# Altium schematic sheet General Overview.SchDoc("General Overview")
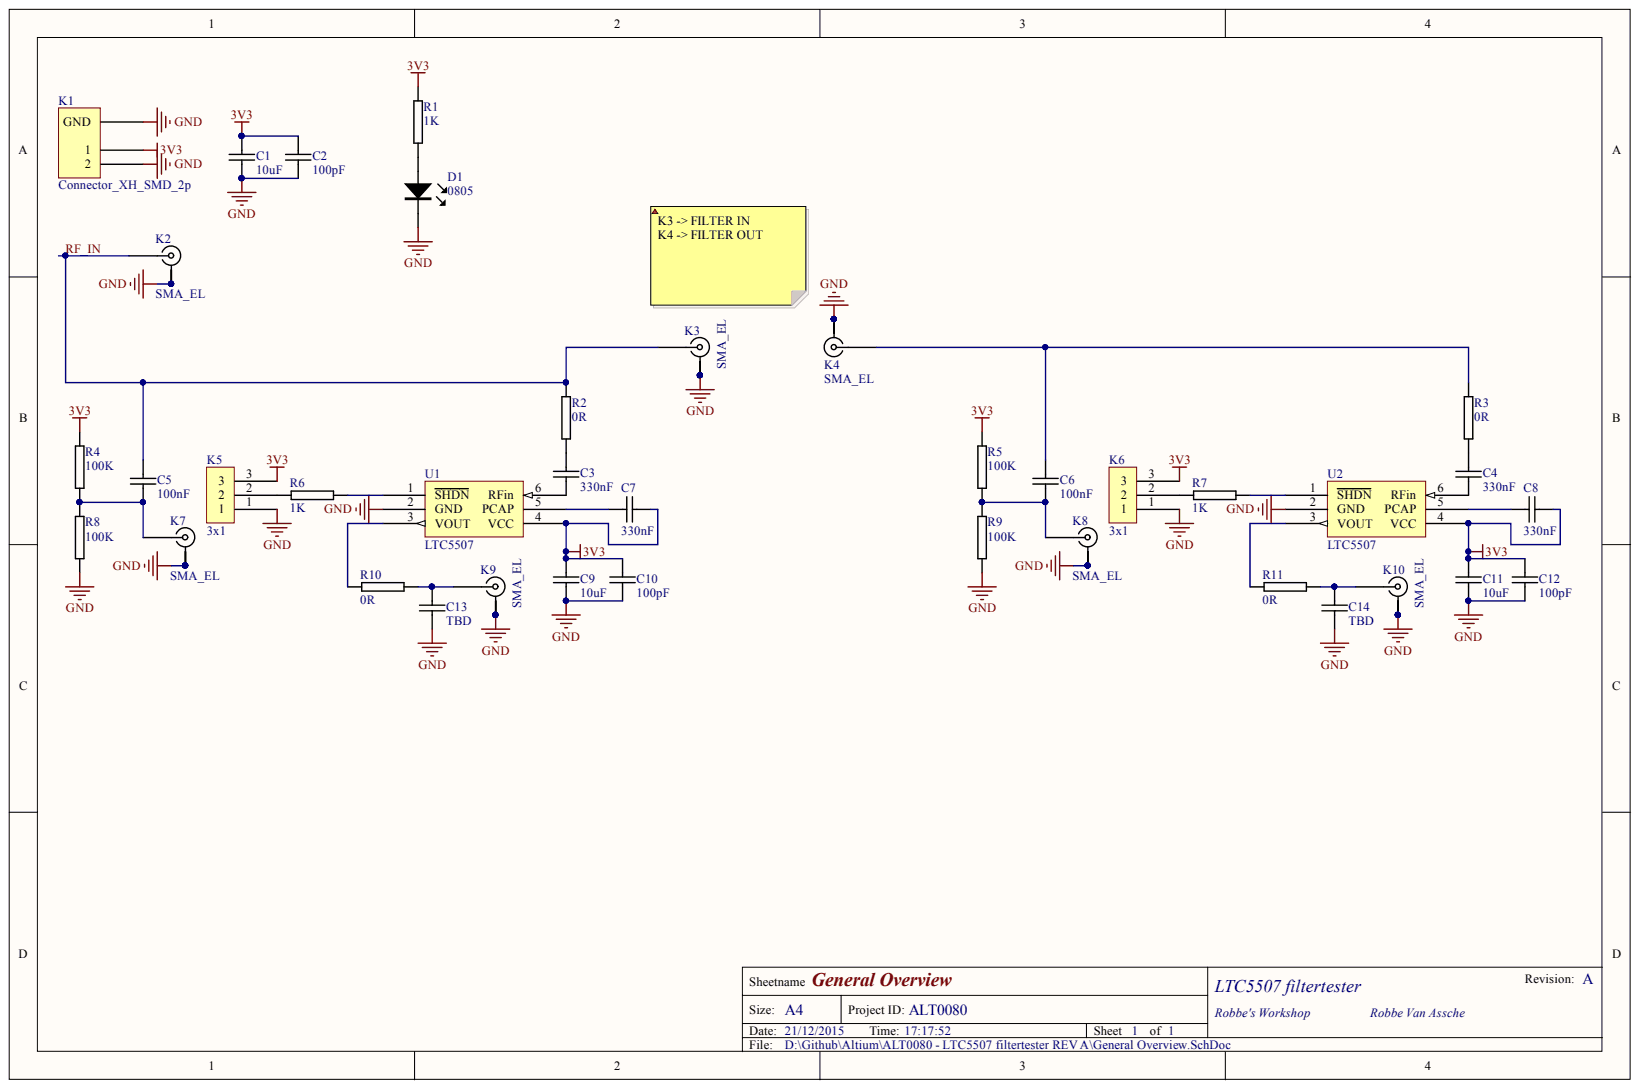
[diagram p0: General Overview.SchDoc]

## Parts
C1  10uF  [1210C-GENERIC]
C2  100pF  [0603C-TBD]
C3  330nF  [0603C-TBD]
C4  330nF  [0603C-TBD]
C5  100nF  [0603C-TBD]
C6  100nF  [0603C-TBD]
C7  330nF  [0603C-TBD]
C8  330nF  [0603C-TBD]
C9  10uF  [1210C-GENERIC]
C10  100pF  [0603C-TBD]
C11  10uF  [1210C-GENERIC]
C12  100pF  [0603C-TBD]
C13  TBD  [0603C-TBD]
C14  TBD  [0603C-TBD]
D1  0805  [0805LED]
K1  Connector_XH_SMD_2p  [2.54_2p]
K2  SMA_EL  [SMA_ENDLAUNCH]
K3  SMA_EL  [SMA_ENDLAUNCH]
K4  SMA_EL  [SMA_ENDLAUNCH]
K5  3x1  [Header_Male_2.54 3x1]
K6  3x1  [Header_Male_2.54 3x1]
K7  SMA_EL  [SMA_ENDLAUNCH]
K8  SMA_EL  [SMA_ENDLAUNCH]
K9  SMA_EL  [SMA_ENDLAUNCH]
K10  SMA_EL  [SMA_ENDLAUNCH]
R1  1K  [0603R-1k]
R2  0R  [0603R-0R]
R3  0R  [0603R-0R]
R4  100K  [0603R-100K]
R5  100K  [0603R-100K]
R6  1K  [0603R-1k]
R7  1K  [0603R-1k]
R8  100K  [0603R-100K]
R9  100K  [0603R-100K]
R10  0R  [0603R-0R]
R11  0R  [0603R-0R]
U1  LTC5507  [S6-TSOT-23]
U2  LTC5507  [S6-TSOT-23]

## Nets
3V3: C1.1 C2.1 C7.2 C8.2 C9.1 C10.1 C11.1 C12.1 K1.1 K5.3 K6.3 R1.1 R4.1 R5.1 U1.4(VCC) U2.4(VCC)
GND: C1.2 C2.2 C9.2 C10.2 C11.2 C12.2 C13.1 C14.1 D1.K K1.0 K1.2 K2.2 K2.3 K2.4 K2.5 K3.2 K3.3 K3.4 K3.5 K4.2 K4.3 K4.4 K4.5 K5.1 K6.1 K7.2 K7.3 K7.4 K7.5 K8.2 K8.3 K8.4 K8.5 K9.2 K9.3 K9.4 K9.5 K10.2 K10.3 K10.4 K10.5 R8.2 R9.2 U1.2(GND) U2.2(GND)
RF_IN: C5.1 K2.1 K3.1 R2.2
NetC3_1: C3.1 R2.1
NetC3_2: C3.2 U1.6(RFin)
NetC4_1: C4.1 R3.1
NetC4_2: C4.2 U2.6(RFin)
NetC5_2: C5.2 K7.1 R4.2 R8.1
NetC6_1: C6.1 K4.1 R3.2
NetC6_2: C6.2 K8.1 R5.2 R9.1
NetC7_1: C7.1 U1.5(PCAP)
NetC8_1: C8.1 U2.5(PCAP)
NetC13_2: C13.2 K9.1 R10.2
NetC14_2: C14.2 K10.1 R11.2
NetD1_A: D1.A R1.2
NetK5_2: K5.2 R6.1
NetK6_2: K6.2 R7.1
NetR6_2: R6.2 U1.1(SHDN)
NetR7_2: R7.2 U2.1(SHDN)
NetR10_1: R10.1 U1.3(VOUT)
NetR11_1: R11.1 U2.3(VOUT)

## Library data of the parts
C1 C9 C11 = Passives_Capacitors_1210_1210C-TBD: CAPACITOR 1210 CERAMIC VALUE TO BE DETERMINED; Component Kind: Standard; Library Name: RVA_Passives_Capacitors_1210.SchLib
C2-C8 C10 C12-C14 = Passives_Capacitors_0603_TBD: CAPACITOR 0603 CERAMIC VALUE TO BE DETERMINED; Component Kind: Standard; Library Name: RVA_Passives_Capacitors_0603.SchLib
D1 = LED_SMD_0805: Component Kind: Standard; Library Name: RVA_LED_SMD_0805.SchLib
K2-K4 K7-K10 = RVA_Connector_RF_SMD_SMA-EL: Component Kind: Standard; Description: SMA_EL; Library Name: RVA_Connector_RF_SMD.SchLib
K5 K6 = Connector_Headers_SMD_M_3x1: SMD Header 3x1; Component Kind: Standard; Library Name: RVA_Connector_Headers_SMD.SchLib
R1 R6 R7 = 0603R-1K: GENERIC RESISTOR 0603; Manufacturer: Stackpole Electronics Inc; Manufacturer Part Number: RMCF 1/16 1K 1% R; Reel: R14; Component Kind: Standard; Supplier 1: Digi-Key; Supplier Part Number 1: RMCF0603FT1K00TR-ND; Library Name: RVA_Passives_Resistors_0603.SchLib
R2 R3 R10 R11 = 0603R-0R: GENERIC JUMPER 0603; Manufacturer: Stackpole Electronics Inc; Manufacturer Part Number: RMC 1/16 0 G; Reel: R26; Component Kind: Standard; Supplier 1: Digi-Key; Supplier Part Number 1: RMCF0603ZT0R00TR-ND; Library Name: RVA_Passives_Resistors_0603.SchLib
R4 R5 R8 R9 = 0603R-100K: GENERIC RESISTOR 0603; Manufacturer: Stackpole Electronics Inc; Manufacturer Part Number: RMCF 1/16 100K 1% R; Power (Watts): 0.1W, 1/10W; REEL: R47; Temperature Coefficient: ±100ppm/°C; Tolerance: ±1%; Case: 0603 (1608 metric); Category: Resistors; Component Kind: Standard; Composition: Thick Film; Lead Style: Surface Mount (SMD - SMT); Packaging: Cut Tape (CT); Resistance In Ohms: 100K; RoHS: RoHS Compliant; Supplier: Digi-Key; Supplier 1: Digi-Key; Supplier Part Number: RMCF1/16100KFRCT-ND; Supplier Part Number 1: RMCF0603FT100KTR-ND; Library Name: RVA_Passives_Resistors_0603.SchLib
U1 U2 = RVA_RF_SMD_LTC5507: RF Power Detector; Component Kind: Standard; Library Name: RVA_RF_SMD.SchLib
K1 = Connector_XH_SMD_2p: Component Kind: Standard; Library Name: RVA_Connector_XH_SMD.SchLib
